# Altium schematic sheet VG_CONN.SchDoc(VG_CONN)
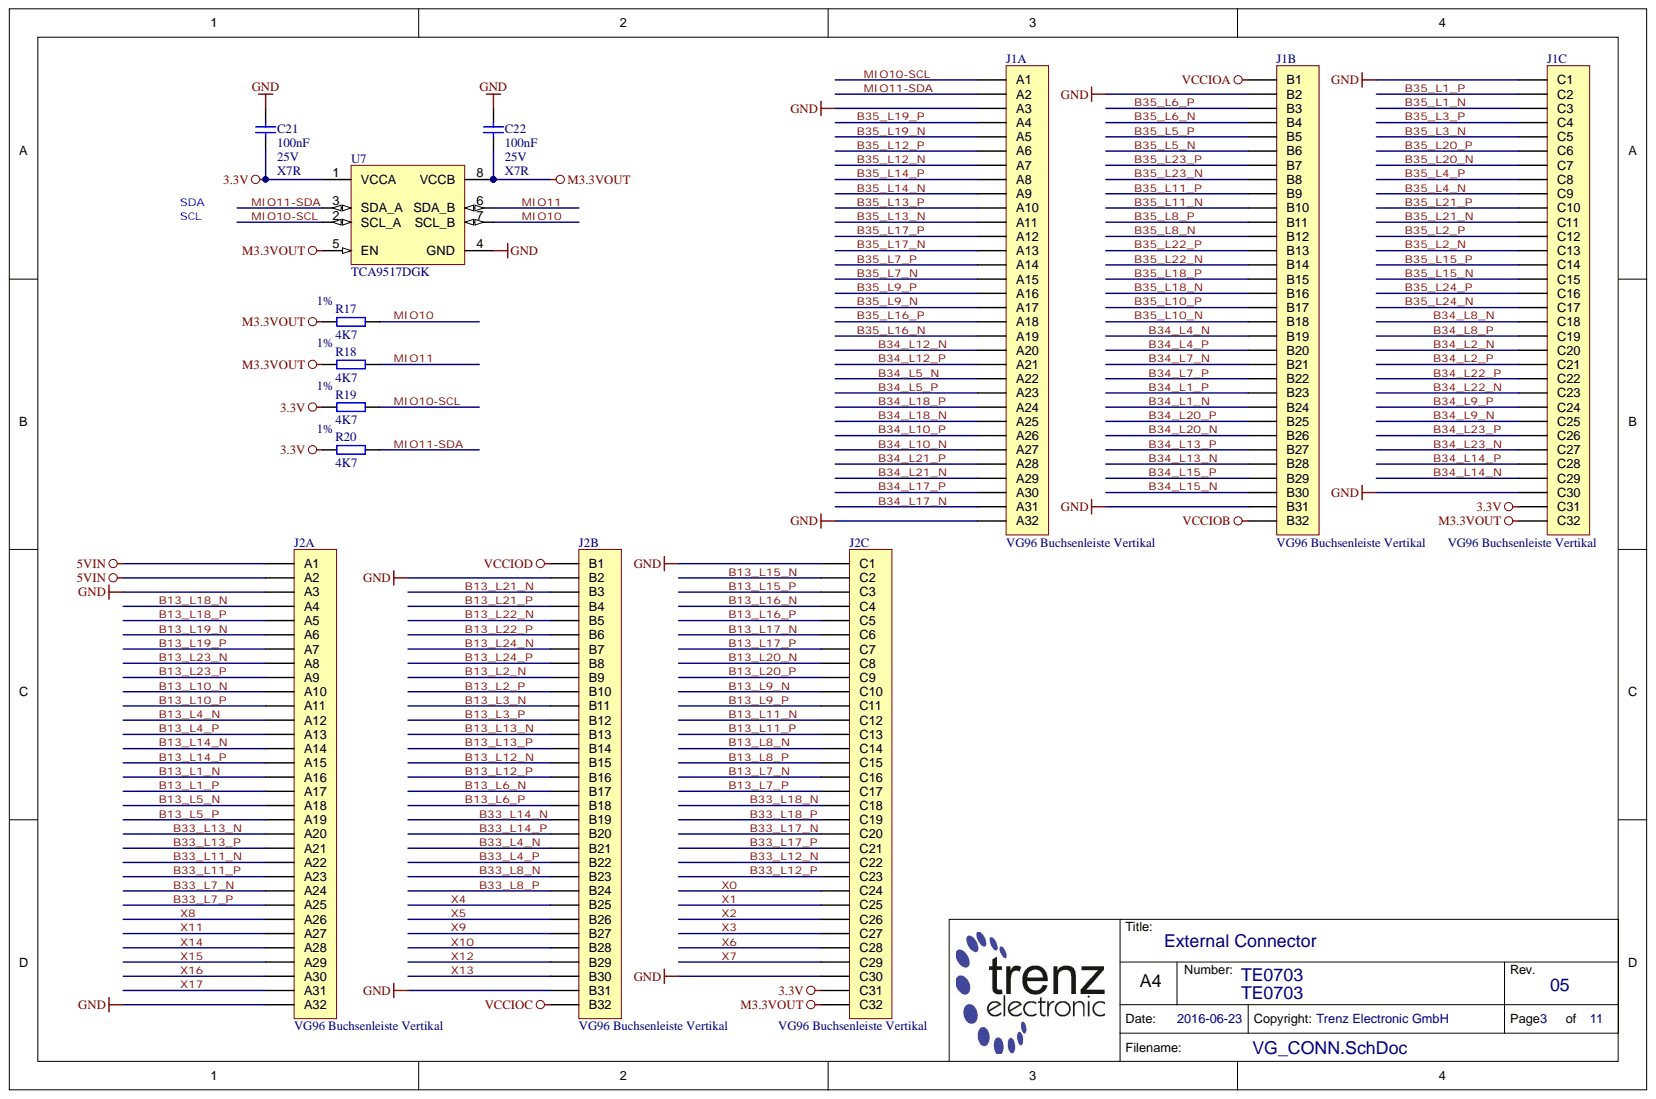
[diagram p0: VG_CONN.SchDoc]

## Parts
C21  100nF  Technology: X7R  Voltage: 25V  [CAPC1005X55N]
C22  100nF  Technology: X7R  Voltage: 25V  [CAPC1005X55N]
J1  VG96 Buchsenleiste Vertikal  [VG96-F-V]  (parts A,B,C)
J2  VG96 Buchsenleiste Vertikal  [VG96-F-V]  (parts A,B,C)
R17  4K7  Tolerance: 1%  [RESC1005X40N]
R18  4K7  Tolerance: 1%  [RESC1005X40N]
R19  4K7  Tolerance: 1%  [RESC1005X40N]
R20  4K7  Tolerance: 1%  [RESC1005X40N]
U7  TCA9517DGK  [SOP65P490X110-8N]

## Nets
3.3V: C21.1 J1.C31 J2.C31 R19.1 R20.1 U7.1(VCCA)
5VIN: J2.A1 J2.A2
GND: C21.2 C22.2 J1.A3 J1.A32 J1.B2 J1.B31 J1.C1 J1.C30 J2.A3 J2.A32 J2.B2 J2.B31 J2.C1 J2.C30 U7.4(GND)
M3.3VOUT: C22.1 J1.C32 J2.C32 R17.1 R18.1 U7.5(EN) U7.8(VCCB)
VCCIOA: J1.B1
VCCIOB: J1.B32
VCCIOC: J2.B32
VCCIOD: J2.B1
B13_L1_N: J2.A16
B13_L1_P: J2.A17
B13_L2_N: J2.B9
B13_L2_P: J2.B10
B13_L3_N: J2.B11
B13_L3_P: J2.B12
B13_L4_N: J2.A12
B13_L4_P: J2.A13
B13_L5_N: J2.A18
B13_L5_P: J2.A19
B13_L6_N: J2.B17
B13_L6_P: J2.B18
B13_L7_N: J2.C16
B13_L7_P: J2.C17
B13_L8_N: J2.C14
B13_L8_P: J2.C15
B13_L9_N: J2.C10
B13_L9_P: J2.C11
B13_L10_N: J2.A10
B13_L10_P: J2.A11
B13_L11_N: J2.C12
B13_L11_P: J2.C13
B13_L12_N: J2.B15
B13_L12_P: J2.B16
B13_L13_N: J2.B13
B13_L13_P: J2.B14
B13_L14_N: J2.A14
B13_L14_P: J2.A15
B13_L15_N: J2.C2
B13_L15_P: J2.C3
B13_L16_N: J2.C4
B13_L16_P: J2.C5
B13_L17_N: J2.C6
B13_L17_P: J2.C7
B13_L18_N: J2.A4
B13_L18_P: J2.A5
B13_L19_N: J2.A6
B13_L19_P: J2.A7
B13_L20_N: J2.C8
B13_L20_P: J2.C9
B13_L21_N: J2.B3
B13_L21_P: J2.B4
B13_L22_N: J2.B5
B13_L22_P: J2.B6
B13_L23_N: J2.A8
B13_L23_P: J2.A9
B13_L24_N: J2.B7
B13_L24_P: J2.B8
B33_L4_N: J2.B21
B33_L4_P: J2.B22
B33_L7_N: J2.A24
B33_L7_P: J2.A25
B33_L8_N: J2.B23
B33_L8_P: J2.B24
B33_L11_N: J2.A22
B33_L11_P: J2.A23
B33_L12_N: J2.C22
B33_L12_P: J2.C23
B33_L13_N: J2.A20
B33_L13_P: J2.A21
B33_L14_N: J2.B19
B33_L14_P: J2.B20
B33_L17_N: J2.C20
B33_L17_P: J2.C21
B33_L18_N: J2.C18
B33_L18_P: J2.C19
B34_L1_N: J1.B24
B34_L1_P: J1.B23
B34_L2_N: J1.C20
B34_L2_P: J1.C21
B34_L4_N: J1.B19
B34_L4_P: J1.B20
B34_L5_N: J1.A22
B34_L5_P: J1.A23
B34_L7_N: J1.B21
B34_L7_P: J1.B22
B34_L8_N: J1.C18
B34_L8_P: J1.C19
B34_L9_N: J1.C25
B34_L9_P: J1.C24
B34_L10_N: J1.A27
B34_L10_P: J1.A26
B34_L12_N: J1.A20
B34_L12_P: J1.A21
B34_L13_N: J1.B28
B34_L13_P: J1.B27
B34_L14_N: J1.C29
B34_L14_P: J1.C28
B34_L15_N: J1.B30
B34_L15_P: J1.B29
B34_L17_N: J1.A31
B34_L17_P: J1.A30
B34_L18_N: J1.A25
B34_L18_P: J1.A24
B34_L20_N: J1.B26
B34_L20_P: J1.B25
B34_L21_N: J1.A29
B34_L21_P: J1.A28
B34_L22_N: J1.C23
B34_L22_P: J1.C22
B34_L23_N: J1.C27
B34_L23_P: J1.C26
B35_L1_N: J1.C3
B35_L1_P: J1.C2
B35_L2_N: J1.C13
B35_L2_P: J1.C12
B35_L3_N: J1.C5
B35_L3_P: J1.C4
B35_L4_N: J1.C9
B35_L4_P: J1.C8
B35_L5_N: J1.B6
B35_L5_P: J1.B5
B35_L6_N: J1.B4
B35_L6_P: J1.B3
B35_L7_N: J1.A15
B35_L7_P: J1.A14
B35_L8_N: J1.B12
B35_L8_P: J1.B11
B35_L9_N: J1.A17
B35_L9_P: J1.A16
B35_L10_N: J1.B18
B35_L10_P: J1.B17
B35_L11_N: J1.B10
B35_L11_P: J1.B9
B35_L12_N: J1.A7
B35_L12_P: J1.A6
B35_L13_N: J1.A11
B35_L13_P: J1.A10
B35_L14_N: J1.A9
B35_L14_P: J1.A8
B35_L15_N: J1.C15
B35_L15_P: J1.C14
B35_L16_N: J1.A19
B35_L16_P: J1.A18
B35_L17_N: J1.A13
B35_L17_P: J1.A12
B35_L18_N: J1.B16
B35_L18_P: J1.B15
B35_L19_N: J1.A5
B35_L19_P: J1.A4
B35_L20_N: J1.C7
B35_L20_P: J1.C6
B35_L21_N: J1.C11
B35_L21_P: J1.C10
B35_L22_N: J1.B14
B35_L22_P: J1.B13
B35_L23_N: J1.B8
B35_L23_P: J1.B7
B35_L24_N: J1.C17
B35_L24_P: J1.C16
MIO10: R17.2 U7.7(SCL_B)
MIO10-SCL: J1.A1 R19.2 U7.2(SCL_A)
MIO11: R18.2 U7.6(SDA_B)
MIO11-SDA: J1.A2 R20.2 U7.3(SDA_A)
X0: J2.C24
X1: J2.C25
X2: J2.C26
X3: J2.C27
X4: J2.B25
X5: J2.B26
X6: J2.C28
X7: J2.C29
X8: J2.A26
X9: J2.B27
X10: J2.B28
X11: J2.A27
X12: J2.B29
X13: J2.B30
X14: J2.A28
X15: J2.A29
X16: J2.A30
X17: J2.A31

## Library data of the parts
C21 C22 = 22472: KON 100nF 25V X5R SMD; Database Table Name: C_1005_0402; Mixed: SMT; Preferred: Yes; Value: 100n; AN: 22472; Case: 1005 (0402); Component Kind: Standard; Library Name: TE.DbLib
J1 J2 = 21545: VG96 Buchsenleiste Vertikal (DIN 41612); Database Table Name: CON; Mixed: THT; Trenz-Stock: Yes; AN: 21545; Component Kind: Standard; Library Name: TE.DbLib; HelpURL: B:\AD\adlib\datasheet\VG96
R17-R20 = 22585: WID SMD 4K7 1% 0,063W; Database Table Name: R_1005_0402; Mixed: SMT; Preferred: Yes; Value: 4.7K; AN: 22585; Case: 1005 (0402); Component Kind: Standard; Library Name: TE.DbLib; Technology: TK100
U7 = 25562: Database Table Name: IF; Mixed: SMT; Trenz-Stock: Yes; AN: 25562; Case: MSOP8; Component Kind: Standard; Description: TCA9517DGK; H-ART: TCA9517DGK; Library Name: TE.DbLib; HelpURL: B:\AD\adlib\datasheet\TCA9517
